# Altium schematic sheet ws2812esp32_v1.0.SchDoc
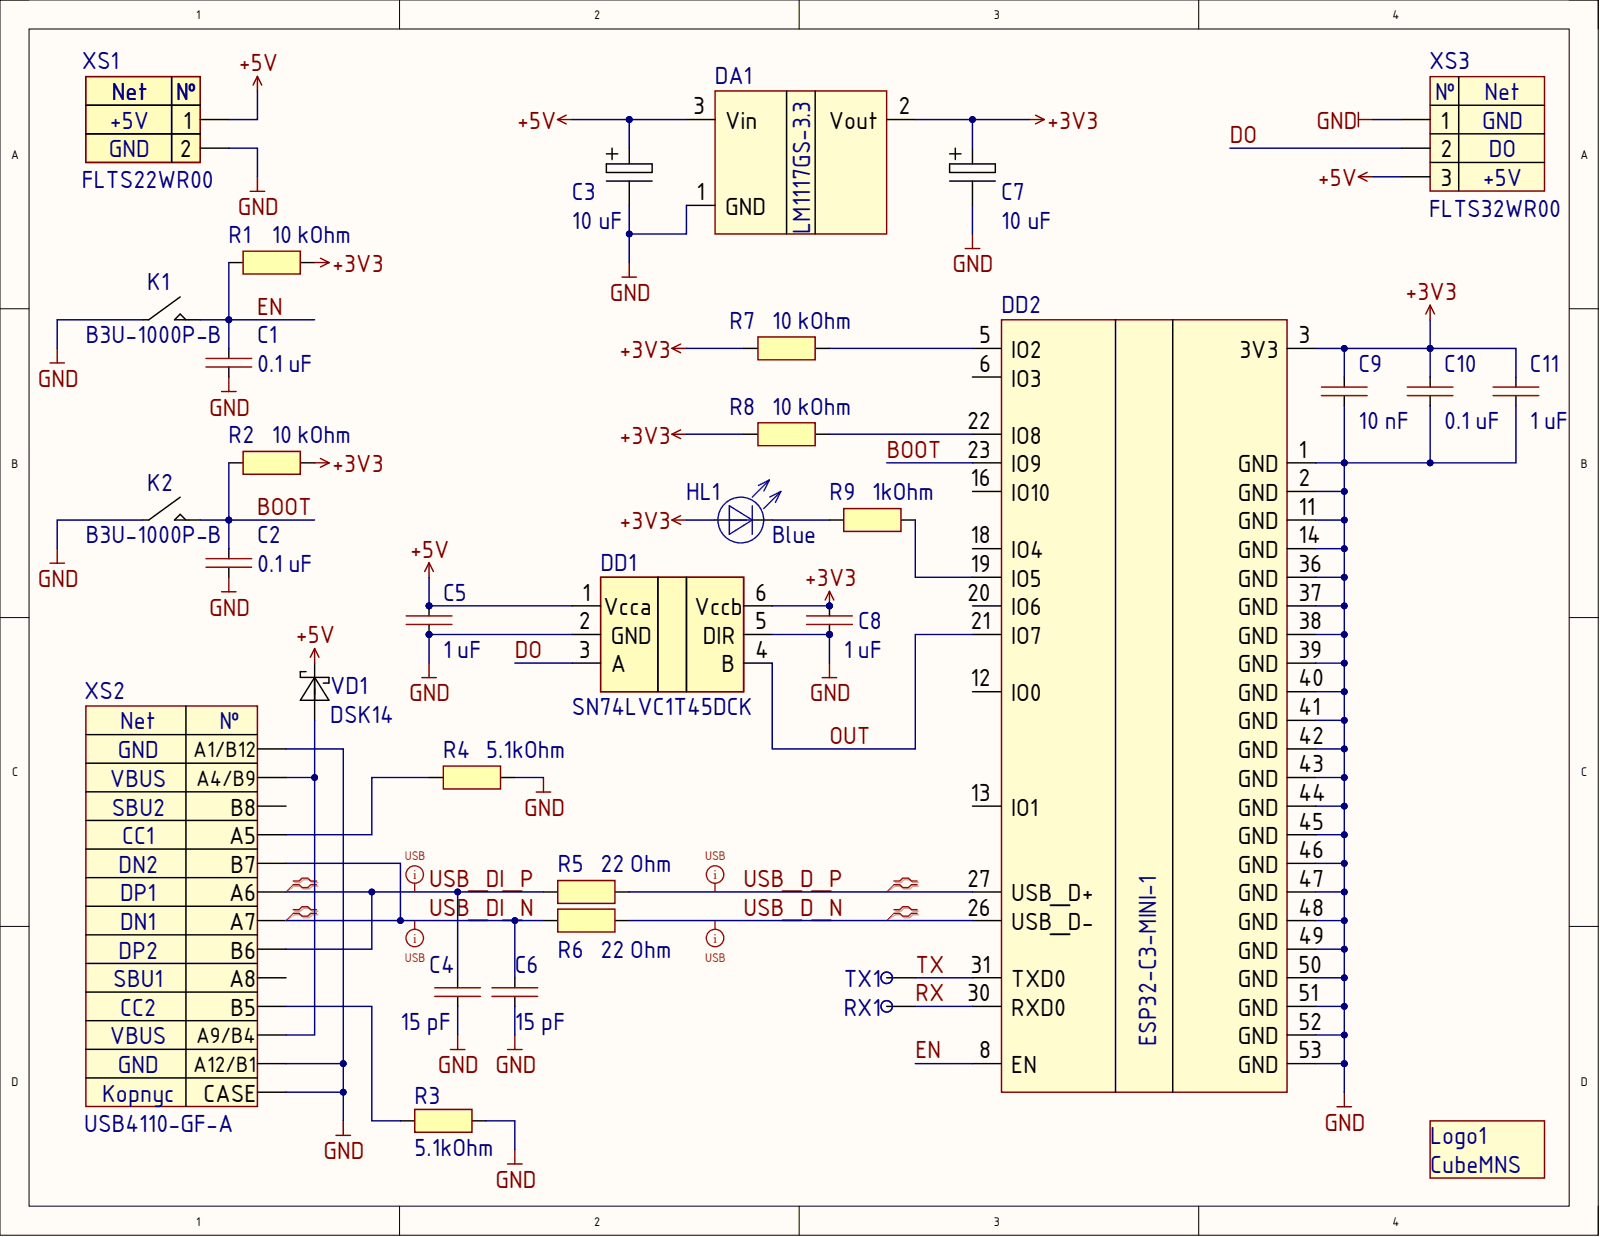
[diagram p0: ws2812esp32_v1.0.SchDoc]

## Parts
C1  0.1 uF  [C0402]
C2  0.1 uF  [C0402]
C3  10 uF  [C tantalin Type A]
C4  15 pF  [C0402]
C5  1 uF  [C0402]
C6  15 pF  [C0402]
C7  10 uF  [C tantalin Type A]
C8  1 uF  [C0402]
C9  10 nF  [C0402]
C10  0.1 uF  [C0402]
C11  1 uF  [C0402]
DA1  LM1117GS-3.3  [SOT223]
DD1  SN74LVC1T45DCK  [SC-70-6]
DD2  ESP32-C3-MINI-1  [ESP32-C3-MINI-1]
HL1  Blue  [LED0603]
K1  B3U-1000P-B  [B3U-1000P-B]
K2  B3U-1000P-B  [B3U-1000P-B]
Logo1  CubeMNS  [CubeMNS]  (no BOM)
R1  10 kOhm  [R0402]
R2  10 kOhm  [R0402]
R3  5.1kOhm  [R0402]
R4  5.1kOhm  [R0402]
R5  22 Ohm  [R0402]
R6  22 Ohm  [R0402]
R7  10 kOhm  [R0402]
R8  10 kOhm  [R0402]
R9  1kOhm  [R0402]
RX1  (no value)  [СontactPad0.5]  (no BOM)
TX1  (no value)  [СontactPad0.5]  (no BOM)
VD1  DSK14  [SOD-123F]
XS1  FLTS22WR00  [FLTS22WR00]
XS2  USB4110-GF-A  [USB4110-GF-A]
XS3  FLTS32WR00  [FLTS32WR00]

## Nets
+3V3: C7.1 C8.2 C9.2 C10.2 C11.2 DA1.2 DD1.6(Vccb) DD2.3(3V3) HL1.2 R1.1 R2.1 R7.2 R8.2
+5V: C3.1 C5.2 DA1.3 DD1.1(Vcca) VD1.1 XS1.1 XS3.3
GND: C1.1 C2.1 C3.2 C4.1 C5.1 C6.1 C7.2 C8.1 C9.1 C10.1 C11.1 DA1.1 DD1.2(GND) DD1.5(DIR) DD2.1(GND) DD2.2(GND) DD2.11(GND) DD2.14(GND) DD2.36(GND) DD2.37(GND) DD2.38(GND) DD2.39(GND) DD2.40(GND) DD2.41(GND) DD2.42(GND) DD2.43(GND) DD2.44(GND) DD2.45(GND) DD2.46(GND) DD2.47(GND) DD2.48(GND) DD2.49(GND) DD2.50(GND) DD2.51(GND) DD2.52(GND) DD2.53(GND) K1.1 K2.1 R3.2 R4.2 XS1.2 XS2.0(CASE) XS2.A1/B12 XS2.A12/B1 XS3.1
BOOT: C2.2 DD2.23(IO9) K2.2 R2.2
DO: DD1.3(A) XS3.2
EN: C1.2 DD2.8(EN) K1.2 R1.2
OUT: DD1.4(B) DD2.21(IO7)
RX: DD2.30(RXD0) RX1.1
TX: DD2.31(TXD0) TX1.1
USB_D_N: DD2.26(USB_D-) R6.2
USB_D_P: DD2.27(USB_D+) R5.2
USB_DI_N: C6.2 R6.1 XS2.A7 XS2.B7
USB_DI_P: C4.2 R5.1 XS2.A6 XS2.B6
NetDD2_5: DD2.5(IO2) R7.1
NetDD2_19: DD2.19(IO5) R9.2
NetDD2_22: DD2.22(IO8) R8.1
NetHL1_1: HL1.1 R9.1
NetR3_1: R3.1 XS2.B5
NetR4_1: R4.1 XS2.A5
NetVD1_2: VD1.2 XS2.A4/B9 XS2.A9/B4

Not connected: DD2 6(IO3) 12(IO0) 13(IO1) 16(IO10) 18(IO4) 20(IO6); XS2 A8 B8

## Library data of the parts
C1 C2 C10 = C0402 0.1uF 10% 25V: CAP CER 0.1UF 50V X7R 0402; Manufacture: Murata; Part Number: GRM155R71H104KE14D; Ohm/H/F: 0.0000001; Seller: DigiKey; Tolerance: 10; Case: 0402; Case Type: SMD; Component Kind: Standard; Library Name: ws2812esp32_v1.0_Schlib.SchLib; Seller Link: https://www.digikey.com/en/products/detail/murata-electronics/GRM155R71H104KE14D/4906220; Temperature max: +85; Temperature min: -40; Value: 0.1 uF; Voltage: 50V
C3 C7 = C tantalum type A 10uF: CAP TANT 10UF 10% 10V 1206; Manufacture: Kemet; Part Number: T491A106K010AT; Analog: XiangJiang CA45A010K106TA; Ohm/H/F: 0.000010; Seller: DigiKey; Case: Molded A; Case Type: SMD; Component Kind: Standard; Library Name: ws2812esp32_v1.0_Schlib.SchLib; Seller Link: https://www.digikey.com/en/products/detail/kemet/T491A106K010AT/818545; Temperature max: +85; Temperature min: -40; Tolerance: 10%; Value: 10 uF; Voltage: 10V
C4 C6 = C0402 15pF 5% 25V: CAP CER 15PF 50V C0G/NP0 0402; Manufacture: Murata; Part Number: GRM1555C1H150JA01D; Ohm/H/F: 0.000000000015; Seller: DigiKey; Tolerance: 5; Case: 0402; Case Type: SMD; Component Kind: Standard; Library Name: ws2812esp32_v1.0_Schlib.SchLib; Seller Link: https://www.digikey.com/en/products/detail/murata-electronics/GRM1555C1H150JA01D/2791374; Temperature max: +85; Temperature min: -40; Value: 15 pF; Voltage: 50V
C5 C8 C11 = C0402 1uF 10% 25V: CAP CER 1UF 25V X5R 0402; Manufacture: Murata; Part Number: GRM155R61E105KA12D; Seller: DigiKey; Case: 0402; Case Type: SMD; Component Kind: Standard; Library Name: ws2812esp32_v1.0_Schlib.SchLib; Seller Link: https://www.digikey.com/en/products/detail/murata-electronics/GRM155R61E105KA12D/4905139; Temperature max: +85; Temperature min: -40; Value: 1 uF; Voltage: 25V
C9 = C0402 10nF 10% 25V: CAP CER 10000PF 25V X7R 0402; Manufacture: Murata; Part Number: GRM155R71E103KA01D; Ohm/H/F: 0.00000001; Seller: DigiKey; Tolerance: 10%; Case: 0402; Case Type: SMD; Component Kind: Standard; Library Name: ws2812esp32_v1.0_Schlib.SchLib; Seller Link: https://www.digikey.com/en/products/detail/murata-electronics/GRM155R71E103KA01D/587223; Temperature max: +85; Temperature min: -40; Value: 10 nF; Voltage: 25V
DA1 = LM1117GS-3.3: IC REG LINEAR 3.3V 1A SOT223-3; Manufacture: Taejin; Analog: ST LDL1117S33R, TI LM1117MP-3.3; Seller: DigiKey; Voltage: +3.3V; Case: SOT-223; Case Type: SMD; Component Kind: Standard; Current: 1 A; Library Name: ws2812esp32_v1.0_Schlib.SchLib; Part Number: LM1117GS-3.3; Seller Link: https://www.digikey.com/en/products/detail/taejin/LM1117GS-3-3/22237426?s=N4IgTCBcDaIDIFkCMKDsBxAygWgMwDpcQBdAXyA; Temperature max: +85; Temperature min: -40; Value: LM1117GS-3.3
DD1 = SN74LVC1T45DCK: IC TRANSLTR BIDIRECTIONAL SC70-6; Manufacture: TI; Seller: DigiKey; Voltage: +5.5V; Case: SC70 DCK; Case Type: SMD; Component Kind: Standard; Library Name: ws2812esp32_v1.0_Schlib.SchLib; Part Number: SN74LVC1T45DCK; Seller Link: https://www.digikey.com/en/products/detail/texas-instruments/SN74LVC1T45DCKR/639456; Temperature max: +85; Temperature min: -40; Value: SN74LVC1T45DCK
DD2 = ESP32-C3-MINI-1: RF TXRX MODULE BT PCB TRACE SMD; Manufacture: Espressif; Current: 350 mA; Seller: DigiKey; Voltage: +3.3V; Case: SMD Module 13.2 x 12.5 x 2.4; Case Type: SMD; Component Kind: Standard; Library Name: ws2812esp32_v1.0_Schlib.SchLib; Part Number: ESP32-C3-MINI-1; Seller Link: https://www.digikey.com/en/products/detail/espressif-systems/ESP32-C3-MINI-1-H4/14548892; Temperature max: +85; Temperature min: -40; Value: ESP32-C3-MINI-1
HL1 = LED 0603 Blue: Manufacture: Kingbright; Part Number: APT1608QBC/D; Current: 20mA; Seller: DigiKey; Voltage: 4V; Case: 0603; Case Type: SMD; Component Kind: Standard; Description: LED 0603 Blue; Library Name: ws2812esp32_v1.0_Schlib.SchLib; Seller Link: https://www.digikey.com/en/products/detail/kingbright/APT1608QBC-D/2163697; Temperature max: +85; Temperature min: -40; Value: Blue
K1 K2 = B3U-1000P-B: SWITCH TACTILE SPST-NO 0.05A 12V; Manufacture: Omron; Seller: DigiKey; Case: 3.0 x 2.5 x 1.85; Case Type: SMD; Component Kind: Standard; Library Name: ws2812esp32_v1.0_Schlib.SchLib; Part Number: B3U-1000P-B; Seller Link: https://www.digikey.com/en/products/detail/omron-electronics-inc-emc-div/B3U-1000P-B/1811777?s=N4IgTCBcDaIEIGYCqBaAjABiwBRXEAugL5A; Temperature max: +85; Temperature min: -40; Value: B3U-1000P-B
R1 R2 R7 R8 = R0402 10kOhm 1%: RES 10K OHM 1/16W 1% 0402 SMD; Manufacture: Yageo; Part Number: RC0402FR-0710KL; Ohm/H/F: 10000; Seller: DigiKey; Watt: 0.063; Case: 0402; Case Type: SMD; Component Kind: Standard; Library Name: ws2812esp32_v1.0_Schlib.SchLib; Seller Link: https://www.digikey.com/en/products/detail/yageo/RC0402FR-0710KL/726523; Temperature max: +85; Temperature min: -40; Tolerance: 1%; Value: 10 kOhm
R3 R4 = R0402 5.1kOhm 1%: RES 5.1K OHM 1/16W 1% 0402 SMD; Manufacture: Yageo; Part Number: RC0402FR-075K1L; Ohm/H/F: 5100; Seller: DigiKey; Watt: 0.063; Case: 0402; Case Type: SMD; Component Kind: Standard; Library Name: ws2812esp32_v1.0_Schlib.SchLib; Seller Link: https://www.digikey.com/en/products/detail/yageo/RC0402FR-075K1L/726624; Temperature max: +85; Temperature min: -40; Tolerance: 1%; Value: 5.1kOhm
R5 R6 = R0402 22Ohm 1%: RES 22 OHM 1/16W 1% 0402 SMD; Manufacture: Yageo; Part Number: RC0402FR-0722RL; Ohm/H/F: 22; Seller: DigiKey; Watt: 0.063; Case: 0402; Case Type: SMD; Component Kind: Standard; Library Name: ws2812esp32_v1.0_Schlib.SchLib; Seller Link: https://www.digikey.com/en/products/detail/yageo/RC0402FR-0722RL/726562; Temperature max: +85; Temperature min: -40; Tolerance: 1%; Value: 22 Ohm
R9 = R0402 1kOhm 1%: RES 1K OHM 1/16W 1% 0402 SMD; Manufacture: Yageo; Part Number: RC0402FR-071KL; Ohm/H/F: 1000; Seller: DigiKey; Watt: 0.063; Case: 0402; Case Type: SMD; Component Kind: Standard; Library Name: ws2812esp32_v1.0_Schlib.SchLib; Seller Link: https://www.digikey.com/en/products/detail/yageo/RC0402FR-071KL/726513; Temperature max: +85; Temperature min: -40; Tolerance: 1%; Value: 1kOhm
RX1 TX1 = Сontact Pad: Round 0.5 mm; Case: D0.5; Case Type: SMD; Library Name: ws2812esp32_v1.0_Schlib.SchLib; Temperature max: +85; Temperature min: -40
VD1 = DSK14: DIODE SCHOTTKY 40V 1A; Manufacture: MDD; Seller: DigiKey; Case: SOD-123FL; Case Type: SMD; Component Kind: Standard; Current: 1A; Library Name: ws2812esp32_v1.0_Schlib.SchLib; Part Number: DSK14; Seller Link: https://www.digikey.com/en/products/detail/nextgen-components/DSK14/14291074; Temperature max: +150; Temperature min: -55; Value: DSK14; Voltage: 40V
XS1 = FLTS22WR00: SMD TERMINAL BLOCK 2 PIN; Manufacture: Amphenol; Analog: WAGO 2060-472/998-404; Current: 7A; Seller: DigiKey; Voltage: 250V; Case: 7.9 x 13.1 x 4.6; Case Type: SMD; Component Kind: Standard; Library Name: ws2812esp32_v1.0_Schlib.SchLib; Part Number: FLTS22WR00; Seller Link: https://www.digikey.com/en/products/detail/amphenol-cs-commercial-products/FLTS22WR00/20422799; Temperature max: +105; Temperature min: -40; Value: FLTS22WR00
XS2 = USB4110-GF-A: CONN RCP USB2.0 TYP C 24P SMD RA; Manufacture: GCT; Analog: Amphenol GSB1C41110SSHR; Current: 5A; Seller: DigiKey; Voltage: 48V; Case: USB2.0 TYP C 24P SMD RA; Case Type: SMD; Component Kind: Standard; Library Name: ws2812esp32_v1.0_Schlib.SchLib; Part Number: USB4110-GF-A; Seller Link: https://www.digikey.com/en/products/detail/gct/USB4110-GF-A/10384547; Temperature max: +85; Temperature min: -40; Value: USB4110-GF-A
XS3 = FLTS32WR00: SMD TERMINAL BLOCK 3 PIN; Manufacture: Amphenol; Analog: WAGO 2060-473/998-404; Current: 7A; Seller: DigiKey; Voltage: 250V; Case: 11.9 x 13.1 x 4.6; Case Type: SMD; Component Kind: Standard; Library Name: ws2812esp32_v1.0_Schlib.SchLib; Part Number: FLTS32WR00; Seller Link: https://www.digikey.com/en/products/detail/amphenol-cs-commercial-products/FLTS32WR00/20426575; Temperature max: +105; Temperature min: -40; Value: FLTS32WR00
Logo1 = CubeMNS: Library Name: ws2812esp32_v1.0_Schlib.SchLib
